# Altium schematic sheet 51CoreBoard.SchDoc
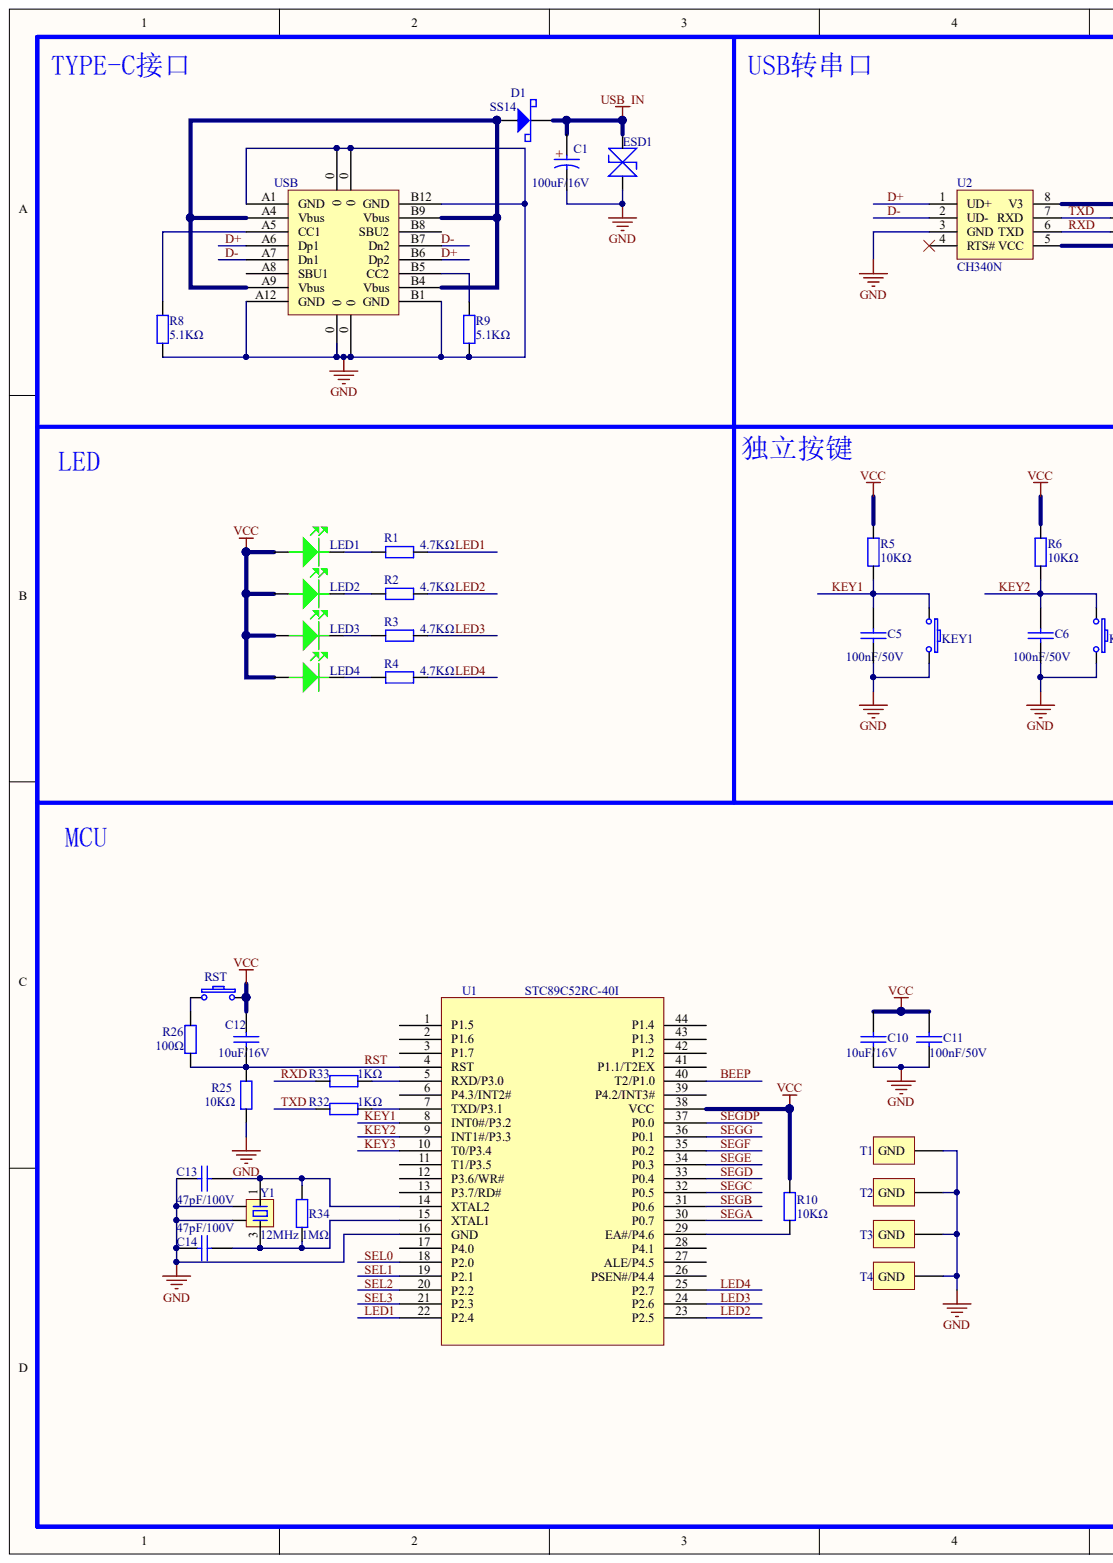
[diagram p0: 51CoreBoard.SchDoc, left]
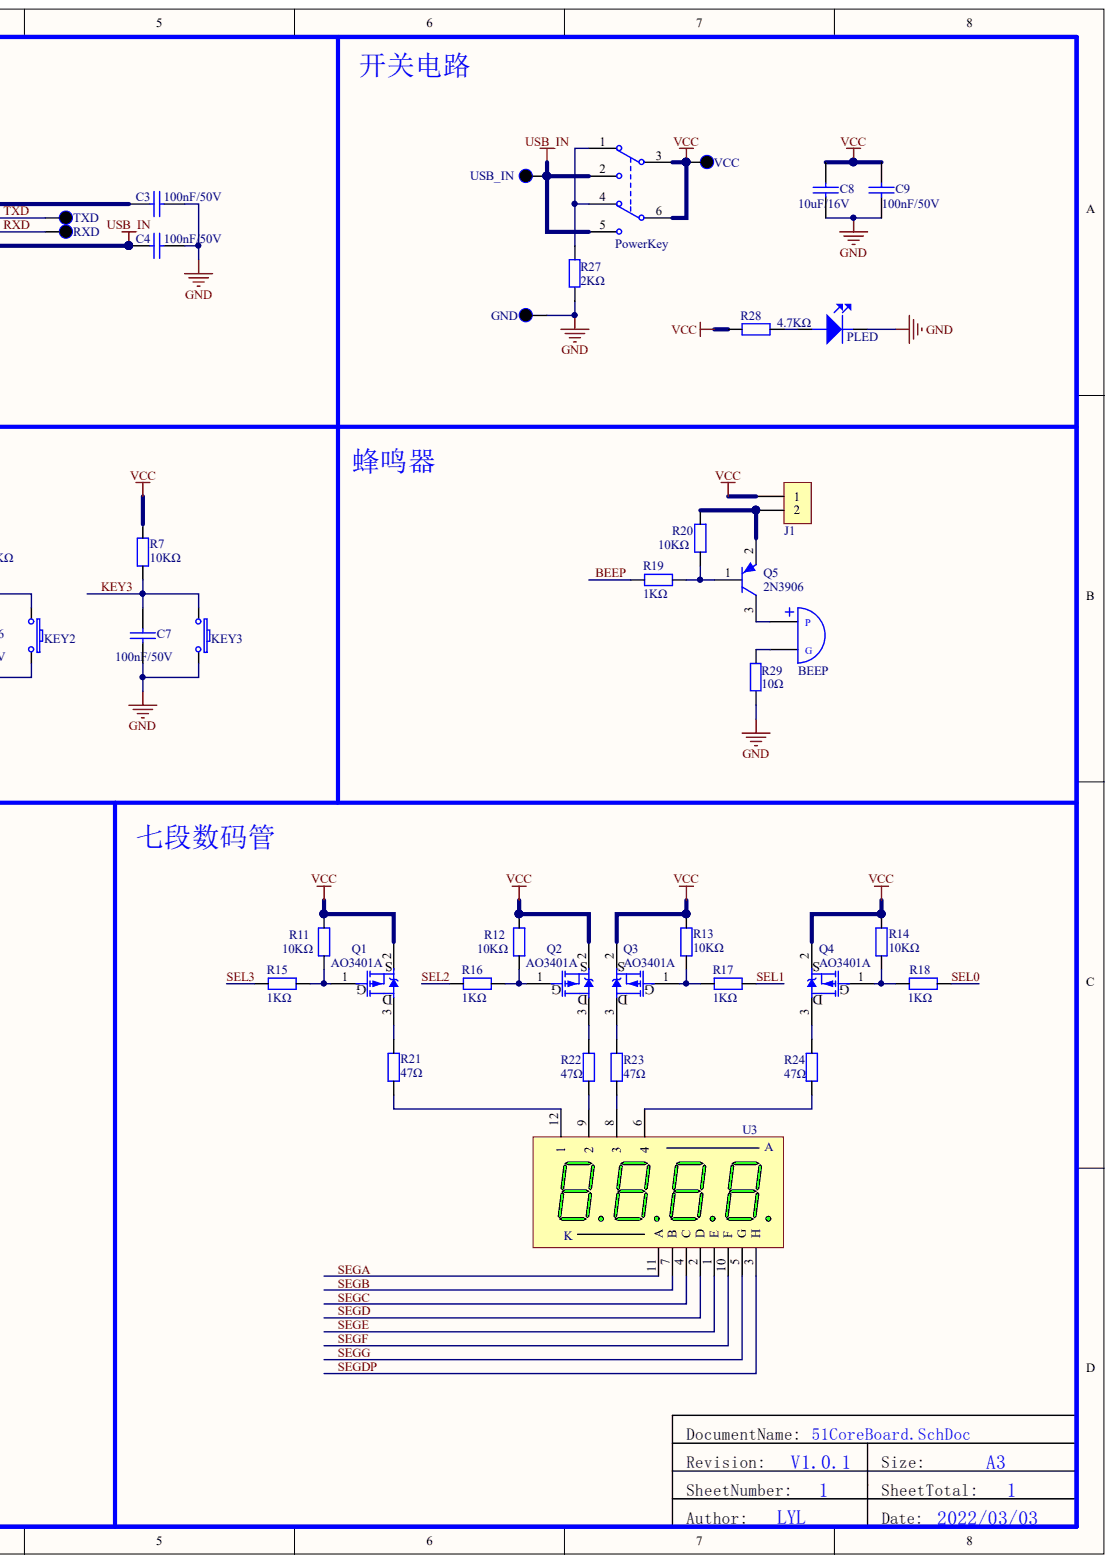
[diagram p1: 51CoreBoard.SchDoc, right]

## Parts
BEEP  电磁蜂鸣器 有源9*5.5  3V  [BEEP 5x9x5.5]  (value not drawn)
C1  value 100uF/16V  [CS 2.0*5*8 - R]  (hidden comment: 100uF 16V直插)
C3  value 100nF/50V  [C 0603]  (hidden comment: 100nF (104) ±10% 50V SMD0603)
C4  value 100nF/50V  [C 0603]  (hidden comment: 100nF (104) ±10% 50V SMD0603)
C5  value 100nF/50V  [C 0603]  (hidden comment: 100nF (104) ±10% 50V SMD0603)
C6  value 100nF/50V  [C 0603]  (hidden comment: 100nF (104) ±10% 50V SMD0603)
C7  value 100nF/50V  [C 0603]  (hidden comment: 100nF (104) ±10% 50V SMD0603)
C8  value 10uF/16V  [C 0603]  (hidden comment: 10uF (106) ±10% 16V SMD0603)
C9  value 100nF/50V  [C 0603]  (hidden comment: 100nF (104) ±10% 50V SMD0603)
C10  value 10uF/16V  [C 0603]  (hidden comment: 10uF (106) ±10% 16V SMD0603)
C11  value 100nF/50V  [C 0603]  (hidden comment: 100nF (104) ±10% 50V SMD0603)
C12  value 10uF/16V  [C 0603]  (hidden comment: 10uF (106) ±10% 16V SMD0603)
C13  value 47pF/100V  [C 0603]  (hidden comment: 47pF ±5% 100V SMD0603)
C14  value 47pF/100V  [C 0603]  (hidden comment: 47pF ±5% 100V SMD0603)
D1  SS14  [SMA]
ESD1  ESD二极管 SMD0603  [L 0603]  (value not drawn)
GND  TESTPOINT_0.9  [TESTPOINT_0.9]  (value not drawn)
J1  排针 单排 2.54mm 2P  [HDR-1x2]  (value not drawn)
KEY1  直插轻触开关 6*6*6mm  [TSW DIP-6*6*6]  (value not drawn)
KEY2  直插轻触开关 6*6*6mm  [TSW DIP-6*6*6]  (value not drawn)
KEY3  直插轻触开关 6*6*6mm  [TSW DIP-6*6*6]  (value not drawn)
LED1  翠绿发光二极管  SMD0805  [LED 0805G]  (value not drawn)
LED2  翠绿发光二极管  SMD0805  [LED 0805G]  (value not drawn)
LED3  翠绿发光二极管  SMD0805  [LED 0805G]  (value not drawn)
LED4  翠绿发光二极管  SMD0805  [LED 0805G]  (value not drawn)
PLED  蓝色发光二极管  SMD0805  [LED 0805B]  (value not drawn)
PowerKey  自锁开关 KFT8.0  [KFT DIP-8X8]  (value not drawn)
Q1  AO3401A  [SOT23-3L]
Q2  AO3401A  [SOT23-3L]
Q3  AO3401A  [SOT23-3L]
Q4  AO3401A  [SOT23-3L]
Q5  2N3906  [SOT23-3L]
R1  value 4.7KΩ  [R 0603]  (hidden comment: 4.7KΩ (4701) ±1% SMD0603)
R2  value 4.7KΩ  [R 0603]  (hidden comment: 4.7KΩ (4701) ±1% SMD0603)
R3  value 4.7KΩ  [R 0603]  (hidden comment: 4.7KΩ (4701) ±1% SMD0603)
R4  value 4.7KΩ  [R 0603]  (hidden comment: 4.7KΩ (4701) ±1% SMD0603)
R5  value 10KΩ  [R 0603]  (hidden comment: 10KΩ (1002) ±1% SMD0402)
R6  value 10KΩ  [R 0603]  (hidden comment: 10KΩ (1002) ±1% SMD0402)
R7  value 10KΩ  [R 0603]  (hidden comment: 10KΩ (1002) ±1% SMD0402)
R8  value 5.1KΩ  [R 0603]  (hidden comment: 5.1KΩ (5101) ±1% SMD0603)
R9  value 5.1KΩ  [R 0603]  (hidden comment: 5.1KΩ (5101) ±1% SMD0603)
R10  value 10KΩ  [R 0603]  (hidden comment: 10KΩ (1002) ±1% SMD0402)
R11  value 10KΩ  [R 0603]  (hidden comment: 10KΩ (1002) ±1% SMD0603)
R12  value 10KΩ  [R 0603]  (hidden comment: 10KΩ (1002) ±1% SMD0603)
R13  value 10KΩ  [R 0603]  (hidden comment: 10KΩ (1002) ±1% SMD0603)
R14  value 10KΩ  [R 0603]  (hidden comment: 10KΩ (1002) ±1% SMD0603)
R15  value 1KΩ  [R 0603]  (hidden comment: 1KΩ (1001) ±1% SMD0603)
R16  value 1KΩ  [R 0603]  (hidden comment: 1KΩ (1001) ±1% SMD0603)
R17  value 1KΩ  [R 0603]  (hidden comment: 1KΩ (1001) ±1% SMD0603)
R18  value 1KΩ  [R 0603]  (hidden comment: 1KΩ (1001) ±1% SMD0603)
R19  value 1KΩ  [R 0603]  (hidden comment: 1KΩ (1001) ±1% SMD0603)
R20  value 10KΩ  [R 0603]  (hidden comment: 10KΩ (1002) ±1% SMD0603)
R21  value 47Ω  [R 0603]  (hidden comment: 47Ω (47R0) ±1% SMD0603)
R22  value 47Ω  [R 0603]  (hidden comment: 47Ω (47R0) ±1% SMD0603)
R23  value 47Ω  [R 0603]  (hidden comment: 47Ω (47R0) ±1% SMD0603)
R24  value 47Ω  [R 0603]  (hidden comment: 47Ω (47R0) ±1% SMD0603)
R25  value 10KΩ  [R 0603]  (hidden comment: 10KΩ (1002) ±1% SMD0402)
R26  value 100Ω  [R 0603]  (hidden comment: 100Ω (1000) ±1% SMD0603)
R27  value 2KΩ  [R 1206]  (hidden comment: 10Ω ±10% 600mW SMD1206)
R28  value 4.7KΩ  [R 0603]  (hidden comment: 4.7KΩ (4701) ±1% SMD0603)
R29  value 10Ω  [R 0603]  (hidden comment: 10Ω (10R0) ±1%  SMD0603)
R32  value 1KΩ  [R 0603]  (hidden comment: 1KΩ (1001) ±1% SMD0603)
R33  value 1KΩ  [R 0603]  (hidden comment: 1KΩ (1001) ±1% SMD0603)
R34  value 1MΩ  [R 0603]  (hidden comment: 1MΩ (1004) ±1% SMD0603)
RST  贴片轻触开关 白头 3*6*2.5  [TSW SMD-3*6*2.5]  (value not drawn)
RXD  TESTPOINT_0.9  [TESTPOINT_0.9]  (value not drawn)
T1  定位孔3.2mm  [定位孔-3.2-4.2]  (value not drawn)
T2  定位孔3.2mm  [定位孔-3.2-4.2]  (value not drawn)
T3  定位孔3.2mm  [定位孔-3.2-4.2]  (value not drawn)
T4  定位孔3.2mm  [定位孔-3.2-4.2]  (value not drawn)
TXD  TESTPOINT_0.9  [TESTPOINT_0.9]  (value not drawn)
U1  STC89C52RC-40I  [LQFP44]
U2  CH340N  [LC-SOP-8_150mil]
U3  四位共阳黄绿色数码管  [SMG 0.36-4P]  (value not drawn)
USB  TYPE-C-31-M-12 母座  [TYPE_C_12P]  (value not drawn)
USB_IN  TESTPOINT_0.9  [TESTPOINT_0.9]  (value not drawn)
VCC  TESTPOINT_0.9  [TESTPOINT_0.9]  (value not drawn)
Y1  Vaule: 12MHz  [XTAL-3225]  (hidden comment: 贴片晶振 SMD3225 12MHZ)

## Nets
GND: C1.2 C3.2 C4.2 C5.2 C6.2 C7.2 C8.2 C9.2 C10.2 C11.2 C13.1 C14.1 ESD1.1 GND.1 KEY1.2 KEY2.2 KEY3.2 PLED.2 R8.2 R9.1 R25.2 R27.1 R29.2 T1.1 T2.1 T3.1 T4.1 U1.16(GND) U2.3(GND) USB.0 USB.A1(GND) USB.A12(GND) USB.B1(GND) USB.B12(GND) Y1.2 Y1.4
USB_IN: C1.1 C4.1 D1.2 ESD1.2 PowerKey.2 PowerKey.5 U2.5(VCC) USB_IN.1
VCC: C8.1 C9.1 C10.1 C11.1 C12.1 J1.1 LED1.1 LED2.1 LED3.1 LED4.1 PowerKey.3 PowerKey.6 Q1.2 Q2.2 Q3.2 Q4.2 R5.1 R6.1 R7.1 R10.1 R11.1 R12.1 R13.1 R14.1 R28.1 RST.2 U1.38(VCC) VCC.1
BEEP: R19.1 U1.40(T2/P1.0)
D+: U2.1(UD+) USB.A6(Dp1) USB.B6(Dp2)
D-: U2.2(UD-) USB.A7(Dn1) USB.B7(Dn2)
KEY1: C5.1 KEY1.1 R5.2 U1.8(INT0#/P3.2)
KEY2: C6.1 KEY2.1 R6.2 U1.9(INT1#/P3.3)
KEY3: C7.1 KEY3.1 R7.2 U1.10(T0/P3.4)
LED1: R1.2 U1.22(P2.4)
LED2: R2.2 U1.23(P2.5)
LED3: R3.2 U1.24(P2.6)
LED4: R4.2 U1.25(P2.7)
RST: C12.2 R25.1 R26.2 U1.4(RST)
RXD: R33.1 RXD.1 U2.6(TXD)
SEGA: U1.30(P0.7) U3.11(A)
SEGB: U1.31(P0.6) U3.7(B)
SEGC: U1.32(P0.5) U3.4(C)
SEGD: U1.33(P0.4) U3.2(D)
SEGDP: U1.37(P0.0) U3.3(H)
SEGE: U1.34(P0.3) U3.1(E)
SEGF: U1.35(P0.2) U3.10(F)
SEGG: U1.36(P0.1) U3.5(G)
SEL0: R18.1 U1.18(P2.0)
SEL1: R17.1 U1.19(P2.1)
SEL2: R16.1 U1.20(P2.2)
SEL3: R15.1 U1.21(P2.3)
TXD: R32.1 TXD.1 U2.7(RXD)
NetBEEP_1: BEEP.1 Q5.3
NetBEEP_2: BEEP.2 R29.1
NetC3_1: C3.1 U2.8(V3)
NetC13_2: C13.2 R34.1 U1.14(XTAL2) Y1.1
NetC14_2: C14.2 R34.2 U1.15(XTAL1) Y1.3
NetD1_1: D1.1 USB.A4(Vbus) USB.A9(Vbus) USB.B4(Vbus) USB.B9(Vbus)
NetJ1_2: J1.2 Q5.2 R20.2
NetLED1_2: LED1.2 R1.1
NetLED2_2: LED2.2 R2.1
NetLED3_2: LED3.2 R3.1
NetLED4_2: LED4.2 R4.1
NetPLED_1: PLED.1 R28.2
NetPowerKey_1: PowerKey.1 PowerKey.4 R27.2
NetQ1_1: Q1.1 R11.2 R15.2
NetQ1_3: Q1.3 R21.1
NetQ2_1: Q2.1 R12.2 R16.2
NetQ2_3: Q2.3 R22.1
NetQ3_1: Q3.1 R13.2 R17.2
NetQ3_3: Q3.3 R23.1
NetQ4_1: Q4.1 R14.2 R18.2
NetQ4_3: Q4.3 R24.1
NetQ5_1: Q5.1 R19.2 R20.1
NetR8_1: R8.1 USB.A5(CC1)
NetR9_2: R9.2 USB.B5(CC2)
NetR10_2: R10.2 U1.29(EA#/P4.6)
NetR21_2: R21.2 U3.12
NetR22_2: R22.2 U3.9
NetR23_2: R23.2 U3.8
NetR24_2: R24.2 U3.6
NetR26_1: R26.1 RST.1
NetR32_2: R32.2 U1.7(TXD/P3.1)
NetR33_2: R33.2 U1.5(RXD/P3.0)

Not connected: U1 1(P1.5) 2(P1.6) 3(P1.7) 6(P4.3/INT2#) 11(T1/P3.5) 12(P3.6/WR#) 13(P3.7/RD#) 17(P4.0) 26(PSEN#/P4.4) 27(ALE/P4.5) 28(P4.1) 39(P4.2/INT3#) 41(P1.1/T2EX) 42(P1.2) 43(P1.3) 44(P1.4); U2 4(RTS#); USB A8(SBU1) B8(SBU2)

## Library data of the parts
BEEP = 电磁蜂鸣器 有源9*5.5  3V: 蜂鸣器，电磁蜂鸣器 有源9*5.5  3V，直插，国产，SUN-09505-3; A.元件编号: C47620; B.元件名称: 有源9*5.5  3V  蜂鸣器; C.元件类别: 蜂鸣器; D.元件型号: SUN-09055-3; E.封装规格: 直插; I.焊盘数量: 2; J.品牌产地: 国产; 单价/元（大批量）: 0.87; 备注: 立创非可贴片元器件; Component Kind: Standard; G.电压: 3V; Library Name: AltiumDesignerLib.IntLib
C1 = 100uF 16V直插: 直插电解电容，100uF/16V ，5x7mm， LC(立创)，100uF 16V 5*7; A.元件编号: C43803; C.元件类别: 直插电解电容; E.封装规格: 5x7mm; F.阻值(Ω)/容值(uF): 100.000000000; G.电压: 16; I.焊盘数量: 2; J.品牌产地: LC(立创); 单价/元（大批量）: 0.03; 原创: SZ-BMQ(Copyright © 2015-2016 SZ-BMQ. All rights reserved.); 备注: 立创非可贴片元器件; B.元件名称: 100uF 16V; Component Kind: Standard; D.元件型号: 100uF 16V 5*7; Library Name: AltiumDesignerLib.SCHLIB
C3-C7 C9 C11 = 100nF (104) ±10% 50V SMD0603: 贴片电容，100nF/50V，±10%，SMD0603，YAGEO（国巨），CC0603KRX7R9BB104; A.元件编号: C14663; C.元件类别: 贴片电容; E.封装规格: 0603; F.阻值(Ω)/容值(uF): 0.1000000000; G.电压: 50.00; I.焊盘数量: 2; J.品牌产地: YAGEO(国巨); 单价/元（大批量）: 0.0106; 原创: SZ-BMQ(Copyright © 2015-2016 SZ-BMQ. All rights reserved.); 备注: 立创可贴片元器件; B.元件名称: 100nF (104) ±10% 50V; Component Kind: Standard; D.元件型号: CC0603KRX7R9BB104; H.精度: ±10%; Library Name: AltiumDesignerLib.IntLib
C8 C10 C12 = 10uF (106) ±10% 16V SMD0603: 贴片电容，10uF/16V，±10% ，SMD0603，FH(风华)，0603X106K160NT; A.元件编号: C70225; C.元件类别: 贴片电容; E.封装规格: 0603; F.阻值(Ω)/容值(uF): 10.0000000000; G.电压: 16.00; I.焊盘数量: 2; J.品牌产地: FH(风华); 单价/元（大批量）: 0.233; 原创: SZ-BMQ(Copyright © 2015-2016 SZ-BMQ. All rights reserved.); 备注: 立创可贴片元器件; B.元件名称: 10uF (106) ±10% 16V; Component Kind: Standard; D.元件型号: 0603X106K160NT; H.精度: ±10%; Library Name: AltiumDesignerLib.IntLib
C13 C14 = 47pF ±5% 100V SMD0603: 贴片电容，47pF ±5% 100V，SMD0603，SAMSUNG(三星)， CL10C470JC8NNNC; A.元件编号: C318679; C.元件类别: 贴片电容; E.封装规格: 0603; F.阻值(Ω)/容值(uF): 0.4700000000; G.电压: 100; H.精度: ±10%; I.焊盘数量: 2; 单价/元（大批量）: 0.025881; 原创: SZ-BMQ(Copyright © 2015-2016 SZ-BMQ. All rights reserved.); 备注: 立创可贴片元器件; B.元件名称: 47pF ±5% 100V; Component Kind: Standard; D.元件型号: CL10C470JC8NNNC; J.品牌产地: SAMSUNG(三星); Library Name: AltiumDesignerLib.SCHLIB
D1 = SS14: 肖特基二极管，SS14，40V/1A，SMA(DO-214AC)，星海，SS14; A.元件编号: C84343; B.元件名称: SS14 编带; C.元件类别: 肖特基二极管; G.电压: 40V，1A，VF=0.55V@1A; I.焊盘数量: 2; J.品牌产地: 星海; 单价/元（大批量）: 0.059; 原创: SZ-BMQ(Copyright © 2015-2016 SZ-BMQ. All rights reserved.); 备注: 立创非可贴片元器件; Component Kind: Standard; D.元件型号: SS14; E.封装规格: SMA(DO-214AC); Library Name: AltiumDesignerLib.IntLib
ESD1 = ESD二极管 SMD0603: ESD二极管，SMD0603， BORN伯恩半导体，0603ESDA-05N; A.元件编号: C316054; E.封装规格: 0603; I.焊盘数量: 2; 单价/元（大批量）: 0.1; 原创: SZ-BMQ(Copyright © 2015-2016 SZ-BMQ. All rights reserved.); 备注: 立创非可贴片元器件; B.元件名称: 0603ESDA-05N; C.元件类别: ESD二极管; Component Kind: Standard; D.元件型号: 0603ESDA-05N; J.品牌产地: BORN伯恩半导体; Library Name: ADLib-20181123-HRZ.IntLib
GND RXD TXD USB_IN VCC = TESTPOINT_0.9: TESTPOINT_0.9，测试点0.9mm，不需焊接; 原创: SZLY; 备注: 测试点不需焊接; B.元件名称: 测试点0.9mm; Component Kind: Standard; Library Name: STM32CoreBoard.IntLib
J1 = 排针 单排 2.54mm 2P: 排针，单排，2.54mm，2P，国产; B.元件名称: 2.54mm 1*2P 直 排针; I.焊盘数量: 2; 单价/元（大批量）: 0.31; 原创: SZ-BMQ(Copyright © 2015-2016 SZ-BMQ. All rights reserved.); 备注: 立创非可贴片元器件，C2337，2.54mm 1*40P 直 排针，后加工; C.元件类别: 排针; Component Kind: Standard; E.封装规格: HDR-1X2; Library Name: FPGA底板.SCHLIB
KEY1-KEY3 = 直插轻触开关 6*6*6mm: 直插轻触开关，6*6*6mm，DIP/6*6*6; A.元件编号: C782808; C.元件类别: 轻触开关; E.封装规格: DIP/6*6*5; I.焊盘数量: 4; J.品牌产地: Yuandi(台湾元迪); 单价/元（大批量）: 0.13; 备注: 立创非可贴片元器件; B.元件名称: 直插轻触开关 6*6*6mm; Component Kind: Standard; D.元件型号: 直插轻触开关 6*6*6mm; Library Name: AltiumDesignerLib.SCHLIB
LED1-LED4 = 翠绿发光二极管  SMD0805: 翠绿发光二极管 ，SMD0805，国产，0805G (翠绿); A.元件编号: C2297; C.元件类别: 发光二极管; E.封装规格: 0805; F.阻值(Ω)/容值(uF): green; I.焊盘数量: 2; J.品牌产地: 国产; 单价/元（大批量）: 0.052; 原创: SZ-BMQ(Copyright © 2015-2016 SZ-BMQ. All rights reserved.); 备注: 立创可贴片元器件; B.元件名称: 翠绿; Component Kind: Standard; D.元件型号: 0805G (翠绿); Library Name: AltiumDesignerLib.IntLib
PLED = 蓝色发光二极管  SMD0805: 蓝色发光二极管，SMD0805，国星光电，FC-A2012BK-470H2; A.元件编号: C84259; B.元件名称: 蓝灯 贴片LED (20-55mcd) 编带; C.元件类别: 发光二极管; E.封装规格: 0805; F.阻值(Ω)/容值(uF): blue; I.焊盘数量: 2; J.品牌产地: 国星光电; 单价/元（大批量）: 0.0735; 备注: 立创可贴片元器件; Component Kind: Standard; D.元件型号: FC-A2012BK-470H2; Library Name: AltiumDesignerLib.IntLib
PowerKey = 自锁开关，KFT8.0，8x8mm: A.元件编号: C318860; C.元件类别: 电子开关; E.封装规格: 8x8mm; I.焊盘数量: 6; J.品牌产地: 国产; 单价/元（大批量）: 0.254; 原创: SZ-BMQ(Copyright © 2015-2016 SZ-BMQ. All rights reserved.); 备注: 立创非可贴片元器件; B.元件名称: 自锁开关 KFT8.0; Component Kind: Standard; D.元件型号: KFT8.0; Description: 自锁开关，KFT8.0，8x8mm; Library Name: AltiumDesignerLib.SCHLIB
Q1-Q4 = AO3401A: P沟道场效应管，AO3401A，P沟道，-30V，-4A，50mΩ@-10V，SOT-23-3L，AOS，AO3401A; A.元件编号: C15127; B.元件名称: AO3401A P道沟 X13A15 SOT-23; C.元件类别: MOS(场效应管); F.阻值(Ω)/容值(uF): 0.0000000000; I.焊盘数量: 4; J.品牌产地: AOS; 单价/元（大批量）: 0.304; 原创: SZ-BMQ(Copyright © 2015-2016 SZ-BMQ. All rights reserved.); 备注: 立创可贴片元器件; Component Kind: Standard; D.元件型号: AO3401A; E.封装规格: SOT-23-3L; Library Name: AltiumDesignerLib.IntLib
Q5 = 2N3906: 三极管，PNP，2N3906，SOT-23，KEC; A.元件编号: C147294; C.元件类别: 三极管; D.元件型号: 2N3906S-RTK/P; E.封装规格: SOT-23(SOT-23-3); I.焊盘数量: 3; J.名牌产地: KEC; 单价/元（大批量）: 0.0476; 原创: SZ-BMQ(Copyright © 2015-2016 SZ-BMQ. All rights reserved.); 备注: 立创非可贴片元器件; B.元件名称: 2N3906; Component Kind: Standard; Library Name: AltiumDesignerLib.SCHLIB
R1-R4 R28 = 4.7KΩ (4701) ±1% SMD0603: 贴片电阻，4.7KΩ，±1%，SMD0603，UniOhm台湾厚声（授权代理），0603WAF4701T5E; A.元件编号: C23162; C.元件类别: 贴片电阻; E.封装规格: 0603; F.阻值(Ω)/容值(uF): 4700.0000000000; I.焊盘数量: 2; 单价/元（大批量）: 0.003; 原创: SZ-BMQ(Copyright © 2015-2016 SZ-BMQ. All rights reserved.); 备注: 立创可贴片元器件; B.元件名称: 4.7KΩ (4701) ±1%; Component Kind: Standard; D.元件型号: 0603WAF4701T5E; H.精度: ±1%; J.品牌产地: UniOhm台湾厚声（授权代理）; Library Name: AltiumDesignerLib.SCHLIB
R5-R7 R10 R25 = 10KΩ (1002) ±1% SMD0402: 贴片电阻，10KΩ，±1%，SMD0402，UniOhm台湾厚声（授权代理），0402WGF1002TCE; A.元件编号: C25744; C.元件类别: 贴片电阻; E.封装规格: 0402; F.阻值(Ω)/容值(uF): 10000.0000000000; I.焊盘数量: 2; 单价/元（大批量）: 0.0022; 原创: SZ-BMQ(Copyright @ 2015-2016 SZ-BMQ. All rights reserved.); 备注: 立创可贴片元器件; B.元件名称: 10KΩ (1002) ±1%; Component Kind: Standard; D.元件型号: 0402WGF1002TCE; H.精度: ±1%; J.品牌产地: UniOhm台湾厚声（授权代理）; Library Name: AltiumDesignerLib.IntLib
R8 R9 = 5.1KΩ (5101) ±1% SMD0603: 贴片电阻，5.1KΩ，±1%，SMD0603，UniOhm台湾厚声（授权代理），0603WAF5101T5E; A.元件编号: C23186; C.元件类别: 贴片电阻; E.封装规格: 0603; F.阻值(Ω)/容值(uF): 5100.0000; I.焊盘数量: 2; 单价/元（大批量）: 0.0026; 原创: SZ-BMQ(Copyright © 2015-2016 SZ-BMQ. All rights reserved.); 备注: 立创可贴片元器件; B.元件名称: 5.1KΩ (5101) ±1%; Component Kind: Standard; D.元件型号: 0603WAF5101T5E; H.精度: ±1%; J.品牌产地: UniOhm台湾厚声（授权代理）; Library Name: AltiumDesignerLib.IntLib
R11-R14 R20 = 10KΩ (1002) ±1% SMD0603: 贴片电阻，10KΩ，±1%，SMD0603，UniOhm台湾厚声（授权代理），0603WAF1002T5E; A.元件编号: C25804; C.元件类别: 贴片电阻; E.封装规格: 0603; F.阻值(Ω)/容值(uF): 10000.0000000000; I.焊盘数量: 2; 单价/元（大批量）: 0.003; 原创: SZ-BMQ(Copyright © 2015-2016 SZ-BMQ. All rights reserved.); 备注: 立创可贴片元器件; B.元件名称: 10KΩ (1002) ±1%; Component Kind: Standard; D.元件型号: 0603WAF1002T5E; H.精度: ±1%; J.品牌产地: UniOhm台湾厚声（授权代理）
  R11-R14: Library Name: AltiumDesignerLib.IntLib
  R20: Library Name: AltiumDesignerLib.SCHLIB
R15-R19 R32 R33 = 1KΩ (1001) ±1% SMD0603: 贴片电阻，1KΩ，±1%，SMD0603，UniOhm台湾厚声（授权代理），0603F1001T5E; A.元件编号: C21190; C.元件类别: 贴片电阻; E.封装规格: 0603; F.阻值(Ω)/容值(uF): 1000.0000000000; I.焊盘数量: 2; 单价/元（大批量）: 0.003; 原创: SZ-BMQ(Copyright © 2015-2016 SZ-BMQ. All rights reserved.); 备注: 立创可贴片元器件; B.元件名称: 1KΩ (1001) ±1%; Component Kind: Standard; D.元件型号: 0603F1001T5E; H.精度: ±1%; J.品牌产地: UniOhm台湾厚声（授权代理）; Library Name: AltiumDesignerLib.IntLib
R21-R24 = 47Ω (47R0) ±1% SMD0603: 贴片电阻，47Ω，±1%，SMD0603，UniOhm台湾厚声（授权代理），0603WAF470JT5E; A.元件编号: C23182; C.元件类别: 贴片电阻; E.封装规格: 0603; F.阻值(Ω)/容值(uF): 47.0000000000; I.焊盘数量: 2; 单价/元（大批量）: 0.003; 原创: SZ-BMQ(Copyright © 2015-2016 SZ-BMQ. All rights reserved.); 备注: 立创可贴片元器件; B.元件名称: 47Ω (47R0) ±1%; Component Kind: Standard; D.元件型号: 0603WAF470JT5E; H.精度: ±1%; J.品牌产地: UniOhm台湾厚声（授权代理）; Library Name: AltiumDesignerLib.SCHLIB
R26 = 100Ω (1000) ±1% SMD0603: 贴片电阻，100Ω， ±1% ，SMD0603，UniOhm台湾厚声（授权代理），0603WAF1000T5E; A.元件编号: C22775; C.元件类别: 贴片电阻; E.封装规格: 0603; F.阻值(Ω)/容值(uF): 100.0000000000; I.焊盘数量: 2; 单价/元（大批量）: 0.0032; 原创: SZ-BMQ(Copyright © 2015-2016 SZ-BMQ. All rights reserved.); 备注: 立创可贴片元器件; B.元件名称: 100Ω (1000) ±1%; Component Kind: Standard; D.元件型号: 0603WAF1000T5E; H.精度: ±1%; J.品牌产地: UniOhm台湾厚声（授权代理）; Library Name: AltiumDesignerLib.SCHLIB
R27 = 10Ω ±10% 600mW SMD1206: 贴片电阻，10Ω ±10% 600mW SMD1206，UNI-ROYAL(厚声)，AS0606K0100T5E; A.元件编号: C560638; C.元件类别: 贴片电阻; E.封装规格: 1206; F.阻值(Ω)/容值(uF): 10.0000000000; H.精度: ±1%; I.焊盘数量: 2; 单价/元（大批量）: 0.003; 原创: SZ-BMQ(Copyright © 2015-2016 SZ-BMQ. All rights reserved.); 备注: 立创可贴片元器件; B.元件名称: 10Ω ±10% 600mW; Component Kind: Standard; D.元件型号: AS0606K0100T5E; J.品牌产地: UNI-ROYAL(厚声); Library Name: AltiumDesignerLib.SCHLIB
R29 = 10Ω (10R0) ±1%  SMD0603: 贴片电阻，10Ω， ±1% ，SMD0603，UniOhm台湾厚声（授权代理），0603WAF100JT5E; A.元件编号: C22859; C.元件类别: 贴片电阻; E.封装规格: 0603; F.阻值(Ω)/容值(uF): 10.0000000000; I.焊盘数量: 2; 单价/元（大批量）: 0.003; 原创: SZ-BMQ(Copyright © 2015-2016 SZ-BMQ. All rights reserved.); 备注: 立创可贴片元器件; B.元件名称: 10Ω (10R0) ±1%; Component Kind: Standard; D.元件型号: 0603WAF100JT5E; H.精度: ±1%; J.品牌产地: UniOhm台湾厚声（授权代理）; Library Name: AltiumDesignerLib.IntLib
R34 = 1MΩ (1004) ±1% SMD0603: 贴片电阻，1MΩ，±1%，SMD0603，UniOhm台湾厚声（授权代理），0603WAF1004T5E; A.元件编号: C22935; C.元件类别: 贴片电阻; E.封装规格: 0603; F.阻值(Ω)/容值(uF): 1000000.0000000000; I.焊盘数量: 2; 单价/元（大批量）: 0.003; 原创: SZ-BMQ(Copyright © 2015-2016 SZ-BMQ. All rights reserved.); 备注: 立创可贴片元器件; B.元件名称: 1MΩ (1004) ±1%; Component Kind: Standard; D.元件型号: 0603WAF1004T5E; H.精度: ±1%; J.品牌产地: UniOhm台湾厚声（授权代理）; Library Name: AltiumDesignerLib.SCHLIB
RST = 贴片轻触开关 白头 3*6*2.5: 贴片轻触开关，  白头，  3*6*2.5，SMD/3*6*2.5; A.元件编号: C71857; C.元件类别: 轻触开关; I.焊盘数量: 2; J.品牌产地: BBJ; 单价/元（大批量）: 0.0965; 原创: SZ-BMQ(Copyright © 2015-2016 SZ-BMQ. All rights reserved.); 备注: 立创非可贴片元器件; B.元件名称: 贴片轻触开关 白头 3*6*2.5; Component Kind: Standard; D.元件型号: 贴片轻触开关 白头 3*6*2.5; E.封装规格: SMD/3*6*2.5; Library Name: AltiumDesignerLib.SCHLIB
T1-T4 = 定位孔3.2mm: 定位孔3.2mm，不需焊接; A.元件编号: SZU00000; F.阻值(Ω)/容值(uF): 0.0000000000; 原创: SZ-BMQ(Copyright © 2015-2016 SZ-BMQ. All rights reserved.); 备注: 定位孔不需焊接; B.元件名称: 定位孔3.2mm; C.元件类别: 定位孔3.2mm; Component Kind: Standard; D.元件型号: 定位孔3.2mm; E.封装规格: 定位孔3.2mm; Library Name: AltiumDesignerLib.IntLib
U1 = STC89C52RC-40I: 单片机(MCU/MPU/SOC)，STC89C52RC-40I，STC(宏晶)， LQFP-44_10x10x08P; A.元件编号: C8707; I.焊盘数量: 44; 单价/元（大批量）: 5.22; 原创: SZ-BMQ(Copyright © 2015-2016 SZ-BMQ. All rights reserved.); 备注: 立创非可贴片元器件; B.元件名称: STC89C52RC-40I; C.元件类别: 单片机(MCU/MPU/SOC); Component Kind: Standard; D.元件型号: STC89C52RC-40I; E.封装规格: LQFP-44_10x10x08P; J.品牌产地: STC(宏晶); Library Name: AltiumDesignerLib.SCHLIB
U2 = CH330N SOP-8_150mil: USB转串口，SOP-8_150mil，WCH(南京沁恒) ， CH340N; A.元件编号: C506813; C.元件类别: USB; I.焊盘数量: 16; 单价/元（大批量）: 1.61; 备注: 立创可贴片元器件; B.元件名称: CH340N; Component Kind: Standard; D.元件型号: CH340N; E.封装规格: SOP-8_150mil; F.阻值(Ω)/容值(uF): CH340N; J.品牌产地: WCH(南京沁恒); Library Name: AltiumDesignerLib.IntLib
U3 = 四位共阳黄绿色数码管: 七段数码管， 四位，共阳，黄绿色，国产，3461BG; C.元件类别: 七段数码管; D.元件型号: 3461BG; I.焊盘数量: 12; 原创: SZ-BMQ(Copyright © 2015-2016 SZ-BMQ. All rights reserved.); 备注: 非立创元器件; B.元件名称: 四位共阳黄绿色数码管; Component Kind: Standard; Library Name: AltiumDesignerLib.SCHLIB
USB = TYPE-C-31-M-12 母座: A.元件编号: C165948; B.元件名称: TYPE-C-31-M-12 母座 12P; E.封装规格: 8.94*7.3mm; I.焊盘数量: 12; J.品牌产地: 韩国韩荣; 单价/元（大批量）: 1.82; C.元件类别: TYPE-C-31-M-12 母座 12P; Component Kind: Standard; D.元件型号: TYPE-C-31-M-12; Description: TYPE-C-31-M-12 母座; Library Name: ADLib-20181123-HRZ.IntLib
Y1 = 贴片晶振 SMD3225 12MHZ: 贴片晶振，12MHZ±10ppm 20pF，SMD-3225，LC（立创），X322512MSB4SI; A.元件编号: C9002; B.元件名称: 12MHZ 20PF 10PPM; F.阻值(Ω)/容值(uF): 12000000.0000000000; I.焊盘数量: 4; J.品牌产地: LC（立创）; 单价/元（大批量）: 0.54; 原创: SZ-BMQ(Copyright © 2015-2016 SZ-BMQ. All rights reserved.); 备注: 立创可贴片元器件; C.元件类别: 贴片晶振; Component Kind: Standard; D.元件型号: X322512MSB4SI; E.封装规格: SMD-3225; Library Name: AltiumDesignerLib.IntLib
